# Altium schematic sheet System.SchDoc(System)
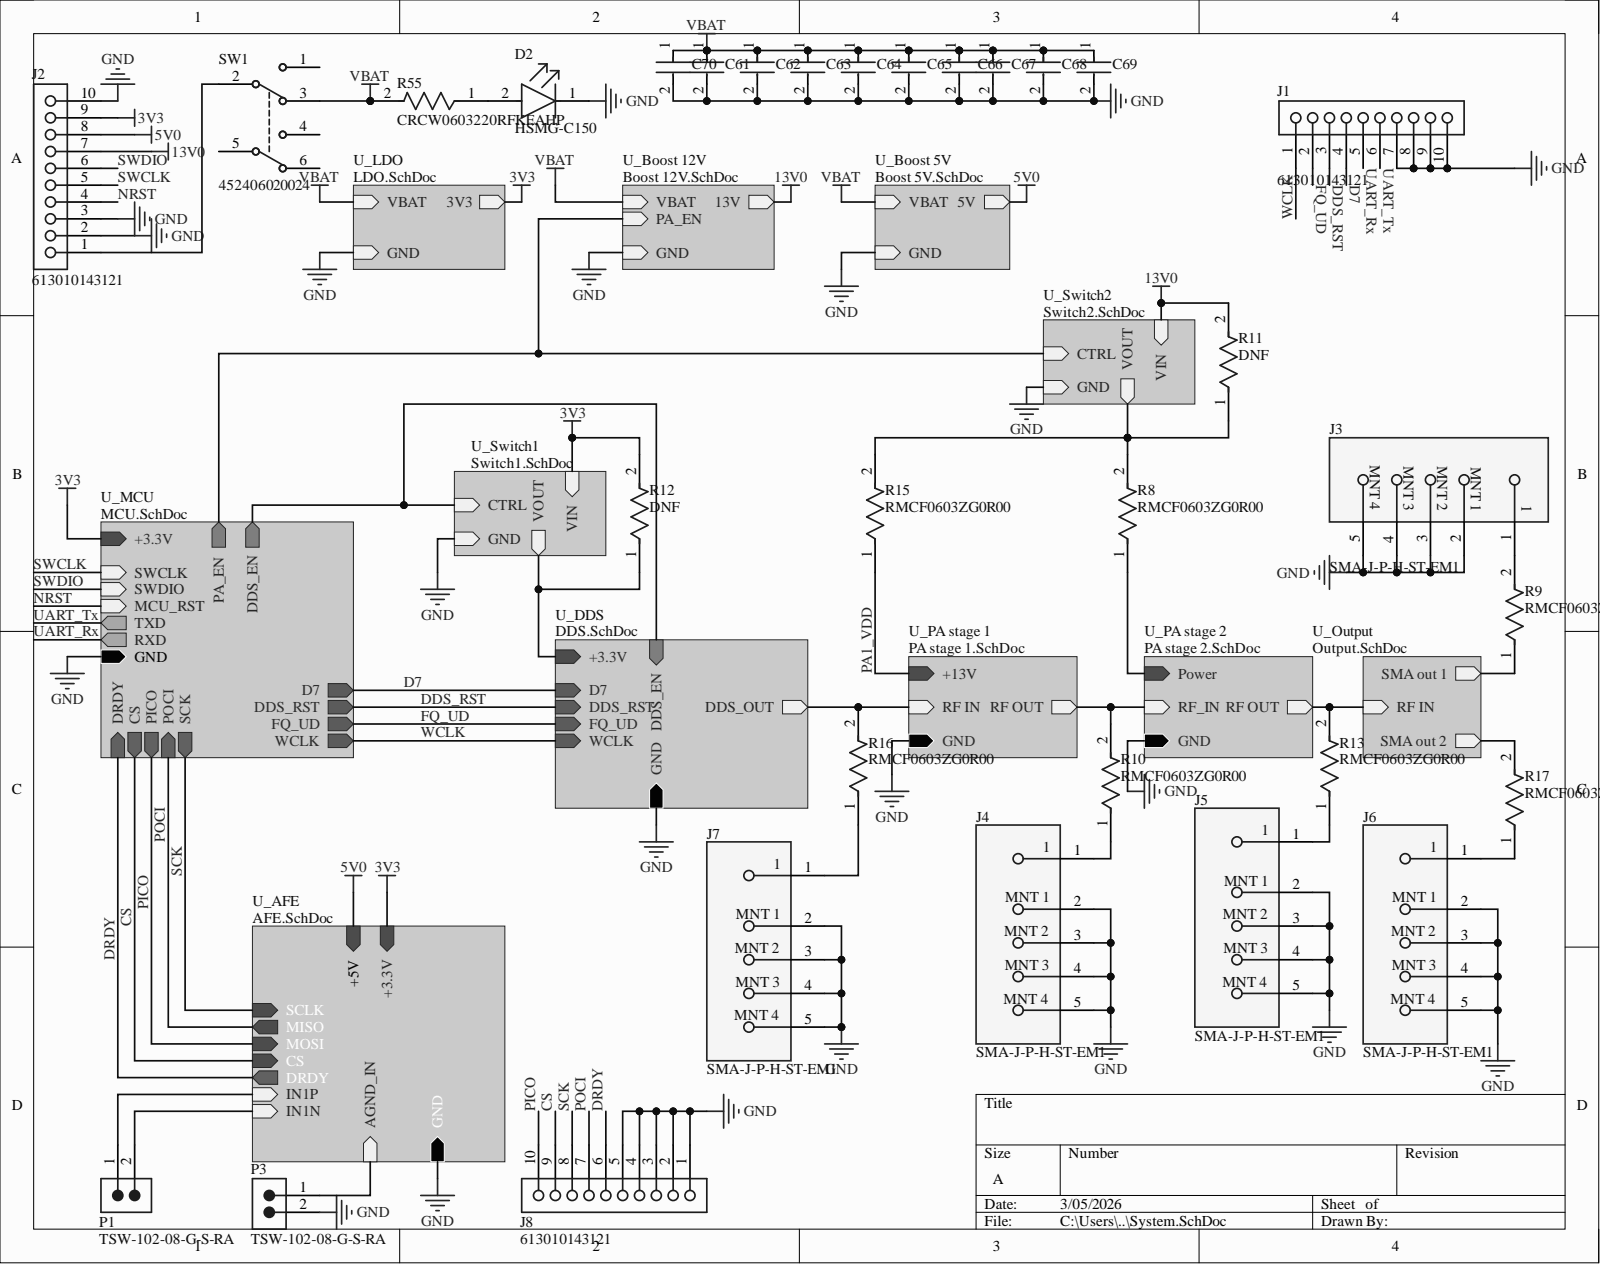
[diagram p0: System.SchDoc]

## Parts
C61  GRM21BR60J107ME15K  [FP-GRM21B-0_2-e0_2_0_7-IPC_B]  (value not drawn)
C62  GRM21BR60J107ME15K  [FP-GRM21B-0_2-e0_2_0_7-IPC_B]  (value not drawn)
C63  GRM21BR60J107ME15K  [FP-GRM21B-0_2-e0_2_0_7-IPC_B]  (value not drawn)
C64  GRM21BR60J107ME15K  [FP-GRM21B-0_2-e0_2_0_7-IPC_B]  (value not drawn)
C65  GRM21BR60J107ME15K  [FP-GRM21B-0_2-e0_2_0_7-IPC_B]  (value not drawn)
C66  GRM21BR60J107ME15K  [FP-GRM21B-0_2-e0_2_0_7-IPC_B]  (value not drawn)
C67  GRM21BR60J107ME15K  [FP-GRM21B-0_2-e0_2_0_7-IPC_B]  (value not drawn)
C68  GRM21BR60J107ME15K  [FP-GRM21B-0_2-e0_2_0_7-IPC_B]  (value not drawn)
C69  GRM21BR60J107ME15K  [FP-GRM21B-0_2-e0_2_0_7-IPC_B]  (value not drawn)
C70  GRM21BR60J107ME15K  [FP-GRM21B-0_2-e0_2_0_7-IPC_B]  (value not drawn)
D2  HSMG-C150  [FP-HSMG-C150-MFG]
J1  613010143121  [613010143121]
J2  613010143121  [613010143121]
J3  SMA-J-P-H-ST-EM1  [FP-SMA-J-P-H-ST-EM1-MFG]
J4  SMA-J-P-H-ST-EM1  [FP-SMA-J-P-H-ST-EM1-MFG]
J5  SMA-J-P-H-ST-EM1  [FP-SMA-J-P-H-ST-EM1-MFG]
J6  SMA-J-P-H-ST-EM1  [FP-SMA-J-P-H-ST-EM1-MFG]
J7  SMA-J-P-H-ST-EM1  [FP-SMA-J-P-H-ST-EM1-MFG]
J8  613010143121  [613010143121]
P1  TSW-102-08-G-S-RA  [SMTC-TSW-102-08-X-S-RA]
P3  TSW-102-08-G-S-RA  [SMTC-TSW-102-08-X-S-RA]
R8  RMCF0603ZG0R00  [FP-RMCF0603-IPC_B]
R9  RMCF0603ZG0R00  [FP-RMCF0603-IPC_B]
R10  RMCF0603ZG0R00  [FP-RMCF0603-IPC_B]
R11  DNF  [FP-RMCF0603-IPC_B]
R12  DNF  [FP-RMCF0603-IPC_B]
R13  RMCF0603ZG0R00  [FP-RMCF0603-IPC_B]
R15  RMCF0603ZG0R00  [FP-RMCF0603-IPC_B]
R16  RMCF0603ZG0R00  [FP-RMCF0603-IPC_B]
R17  RMCF0603ZG0R00  [FP-RMCF0603-IPC_B]
R55  CRCW0603220RFKEAHP  [FP-CRCW0603-HPe3-IPC_A]
SW1  452406020024  [452406020024]

## Nets
3V3: J2.9 R12.2
5V0: J2.8
13V0: J2.7 R11.2
GND: C61.2 C62.2 C63.2 C64.2 C65.2 C66.2 C67.2 C68.2 C69.2 C70.2 D2.1 J1.7 J1.8 J1.9 J1.10 J2.2 J2.3 J2.10 J3.2 J3.3 J3.4 J3.5 J4.2 J4.3 J4.4 J4.5 J5.2 J5.3 J5.4 J5.5 J6.2 J6.3 J6.4 J6.5 J7.2 J7.3 J7.4 J7.5 J8.1 J8.2 J8.3 J8.4 J8.5 P3.2
VBAT: C61.1 C62.1 C63.1 C64.1 C65.1 C66.1 C67.1 C68.1 C69.1 C70.1 R55.2 SW1.3
CS: J8.9
D7: J1.4
DDS_RST: J1.3
DRDY: J8.6
FQ_UD: J1.2
NRST: J2.4
PA1_VDD: R15.1
PICO: J8.10
POCI: J8.7
SCK: J8.8
SWCLK: J2.5
SWDIO: J2.6
UART_Rx: J1.5
UART_Tx: J1.6
WCLK: J1.1
NetD2_2: D2.2 R55.1
NetJ2_1: J2.1 SW1.2
NetJ3_1: J3.1 R9.2
NetJ4_1: J4.1 R10.1
NetJ5_1: J5.1 R13.1
NetJ6_1: J6.1 R17.1
NetJ7_1: J7.1 R16.1
NetP1_1: P1.1
NetP1_2: P1.2
NetP3_1: P3.1
NetR8_1: R8.1
NetR8_2: R8.2 R11.1 R15.2
NetR9_1: R9.1
NetR10_2: R10.2
NetR12_1: R12.1
NetR13_2: R13.2
NetR16_2: R16.2
NetR17_2: R17.2
NetSW1_1: SW1.1
NetSW1_4: SW1.4
NetSW1_5: SW1.5
NetSW1_6: SW1.6
CTRL:

## Library data of the parts
C61-C70 = CMP-06035-052658-1: Chip Multilayer Ceramic Capacitors for General Purpose, 0805, 100uF, X5R, 15%, 20%, 6.3V; Capacitance: 100uF; Component Kind: Standard; Dielectric: X5R; Lead Free: Lead Free; Length: 2mm; Library Name: Altium Content Vault; Thickness: 1.25mm; Tolerance: 20%; Voltage Rating (DC): 6.3V; Width: 1.25mm
D2 = CMP-2000-05061-2: LED GREEN DIFFUSED 1206 SMD; Manufacturer: Broadcom; Forward Current: 20mA; Forward Voltage: 2.2V; Led Orientation: Top View; Luminous Intensity: 15mcd; Max Junction Temp: +95°C; Peak Emmision Wavelength: 570nm; Power Dissipation: 65mW; Reverse Voltage: 5V; Viewing Angle: 170°; Wavelength: 572nm; Automotive: No; Category: Diode; Colour: Green; Component Kind: Standard; ComponentLink2Description: Datasheet; ComponentLink2URL: https://docs.broadcom.com/docs/AV02-0551EN; Device Class L1: Optoelectronics; Device Class L2: LEDs; Device Class L3: unset; Digikey Description: LED GREEN DIFFUSED 1206 SMD; Height: 1.2mm; Ipc Land Pattern Name: DIOCP320160X55; Lead Free: Yes; Library Name: Altium Content Vault; Manufacturer Part Number: HSMG-C150; Mouser Description: LED Uni-Color Green 570nm 2-Pin Chip LED T/R; Octopart: https://octopart.com/hsmg-c150-broadcom-71131304; Package: HSMG-C150; RoHS: TRUE; Temperature: -40°C to +85°C; Temperature Range High: +85°C; Temperature Range Low: -40°C
J1 J2 J8 = CMP-1502-01419-3: NexarPartId: 18818161; Connector Type: Header, Socket; Contact Resistance: 20mΩ; Current Rating: 3A; Gender: Female; Insulation: Insulated; Insulation Resistance: 1GΩ; Number of Contacts: 10; Operating Supply Voltage: 250V; Pitch: 2.54mm; Color: Black; Component Kind: Standard; Contact Gender: Female; Contact Material: Copper; Contact Plating: Gold; Flammability Rating: UL94 V-0; Height: 8.5mm; Housing Material: PBT; Lead Pitch: 2.54mm; Length: 25.9mm; Library Name: Altium Content Vault; Max Operating Temperature: 125°C; Min Operating Temperature: -40°C; Mount: Through Hole; Number of Pins: 10; Number of Positions: 10; Number of Rows: 1; REACH SVHC: Unknown; RoHS: Compliant; Termination: Through Hole; Voltage Rating: 250V; Width: 25.9mm
J3-J7 = CMP-02754-000006-1: CONN SMA JACK STR 50OHM EDGE MNT; Body Material: Brass; Body Plating: Gold; Dielectric Material: PTFE; Impedance: 50R; Max Frequency: 6GHz; Voltage Rating: 335V; Case/Package: SMA; Center Contact Plating: Gold; Component Kind: Standard; Connector Type: SMA; Contact Material: Copper; Contact Plating: Gold; Depth: 13.33mm; Fastening Type: Threaded; Housing Color: Gold; Insulation Material: Polytetrafluoroethylene; Length: 6.35mm; Library Name: Altium Content Vault; Max Operating Temperature: 125°C; Min Operating Temperature: -65°C; Mount: Edge; Orientation: Straight; Package Quantity: 1; Packaging: Tray; Radiation Hardening: No; RoHS Compliant: Yes; Series: SMA; Termination: Solder
P1 P3 = CMP-1024-00320-1: 0.025" SQ Post Header, Through-hole, Right Angle, -55 to 125 degC, 2.54 mm Pitch, 2-Pin, Male, RoHS; Manufacturer: Samtec; Pitch: 2.54 mm; Component Kind: Standard; ComponentLink1Description: Manufacturer URL; ComponentLink1URL: http://www.samtec.com; ComponentLink2Description: Datasheet; ComponentLink2URL: http://www.samtec.com/documents/webfiles/pdf/TSW_TH.PDF; ComponentLink3Description: Package Specification; ComponentLink3URL: http://www.samtec.com/documents/webfiles/cpdf/TSW-XXX-XX-XXX-X-XX-XXX-MKT.pdf; DatasheetVersion: F-214; Library Name: Altium Content Vault; Mounting Technology: Through-hole; Orientation: Right Angle; PackageDescription: .025[0.64] SQ. Post Terminal Strip Assembly; PackageReference: TSW-102-08-X-S-RA; PackageVersion: DM, 8/1988; PartNumber: TSW-102-08-G-S-RA; RoHS: True; Temperature Range: -55 to 125 degC
R8-R13 R15-R17 = CMP-26527-006412-1: 0Ω ±0 0.1W 0603 Thick Film Chip Resistor AEC-Q200 compliant; Max Power Dissipation: 100mW; Resistance: 0R; Case/Package: 0603; Component Kind: Standard; Contact Plating: Tin; Features: Automotive AEC-Q200; Height: 0.022inch; Length: 0.061inch; Library Name: Altium Content Vault; Max Operating Temperature: 155°C; Min Operating Temperature: -55°C; Mount: Surface Mount; Number of Terminations: 2; Packaging: Tape and Reel; Radiation Hardening: No; Resistor Type: Thick Film; RoHS Compliant: Yes; Series: RMCF; Width: 0.031inch
R55 = CMP-02407-003593-1: RES Thick Film, 220Ω, 1%, 0.33W, 100ppm/°C, 0603; Max Power Dissipation: 250mW; Resistance: 220R; Voltage Rating: 75V; Case Code (Imperial): 0603; Case Code (Metric): 1608; Case/Package: 0603; Component Kind: Standard; Composition: Thick Film; Contact Plating: Tin; Depth: 0.85mm; Features: Automotive AEC-Q200; Height: 0.45mm; Lead Free: Lead Free; Length: 1.6mm; Library Name: Altium Content Vault; Max Operating Temperature: 155°C; Military Standard: Not; Min Operating Temperature: -55°C; Mount: Surface Mount; Number of Terminations: 2; Package Quantity: 5000; Packaging: Tape and Reel; Power Rating: 250mW; Radiation Hardening: No; Temperature Coefficient: 100ppm/°C; Termination: SMD/SMT; Tolerance: 1%; Voltage Rating (DC): 75V; Weight: 7.1E-05oz; Width: 0.033inch
SW1 = CMP-03913-146831046-1: Ws-slsv_smt Mini Slide Switch Sa; Component Kind: Standard; Library Name: Altium Content Vault
